# Altium schematic sheet S7CAS-PLEIADES-CARTE-MERE_P07_ETH.SchDoc(S7CAS-PLEIADES-CARTE-MERE_P07_ETH)
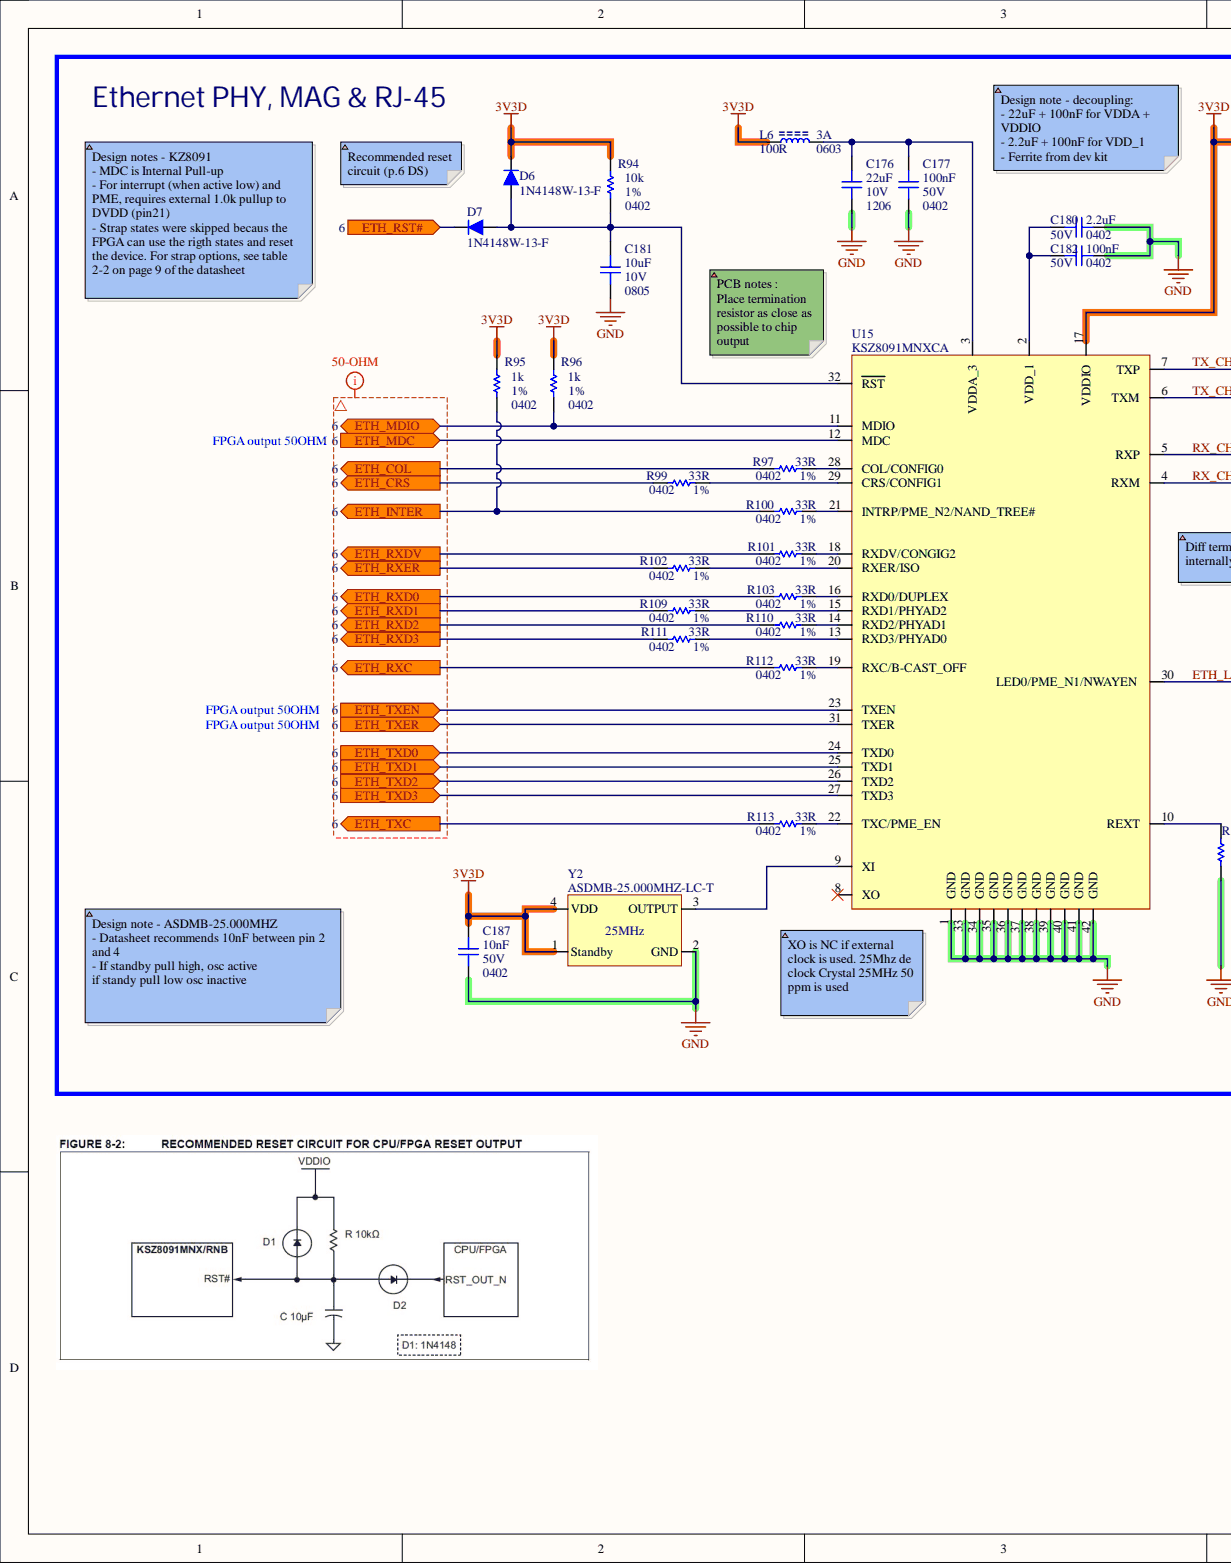
[diagram p0: S7CAS-PLEIADES-CARTE-MERE_P07_ETH.SchDoc, left]
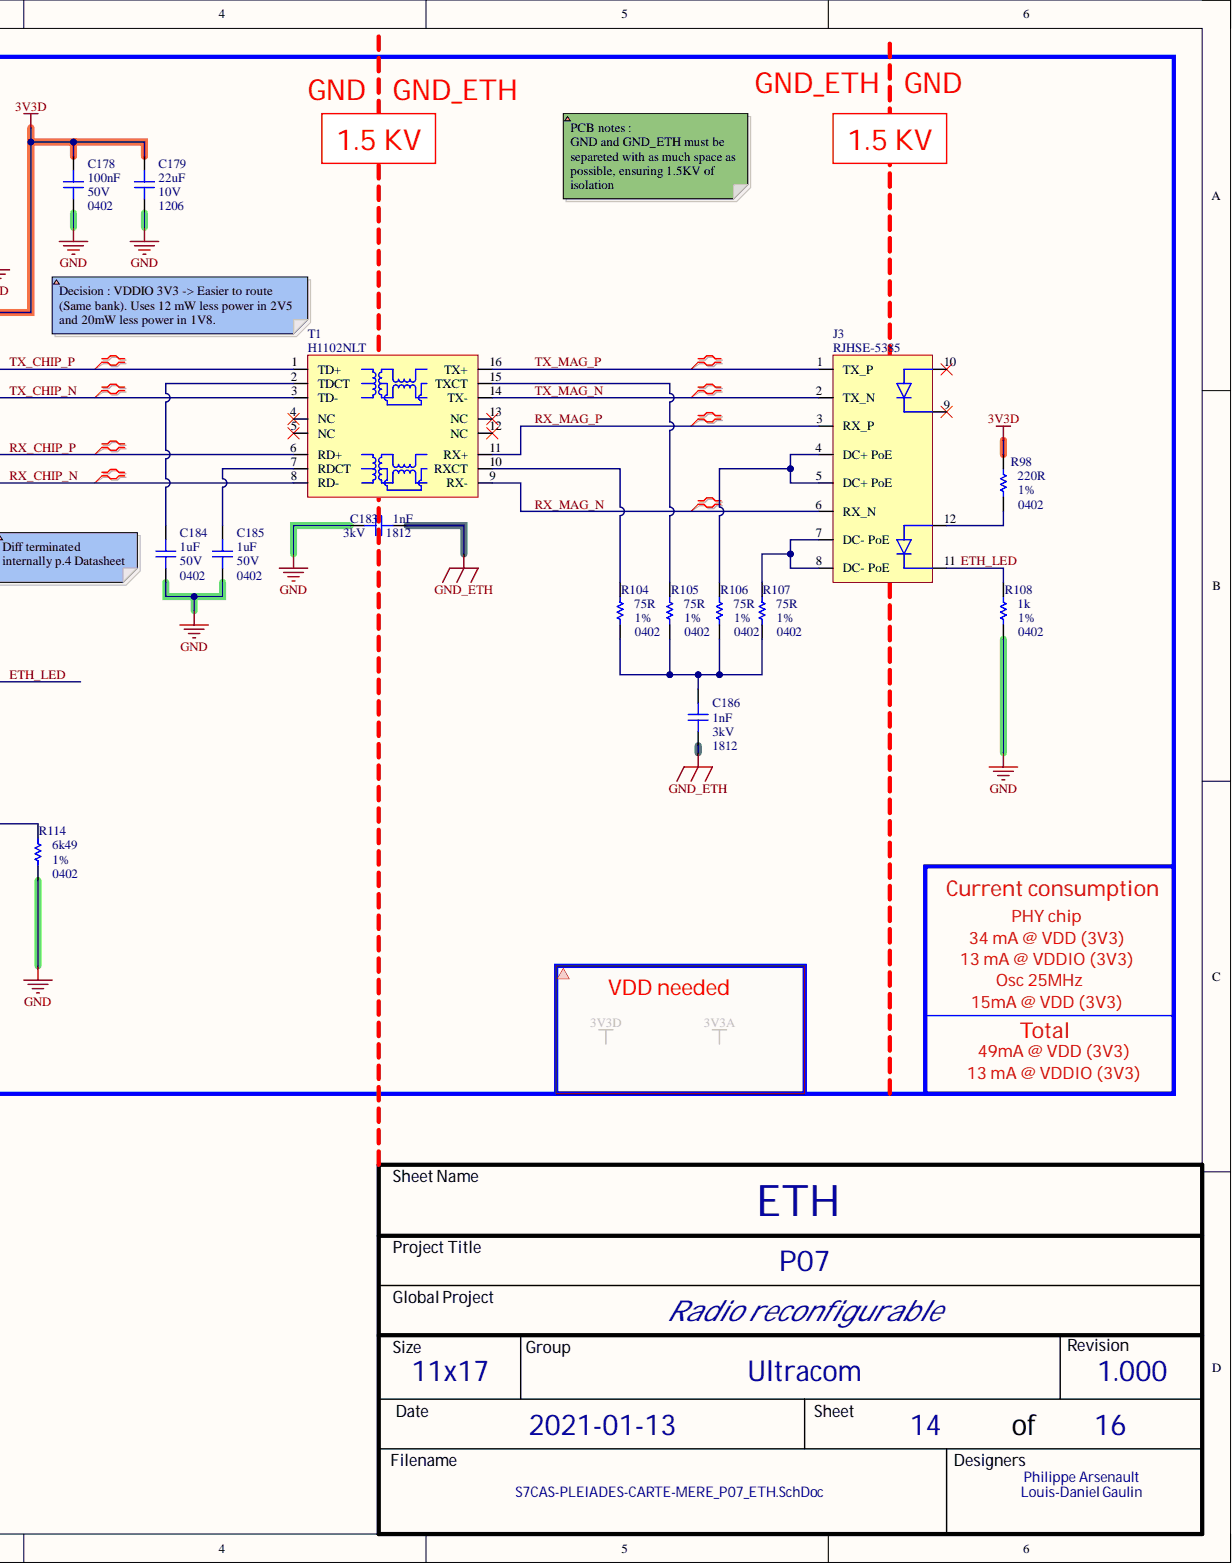
[diagram p1: S7CAS-PLEIADES-CARTE-MERE_P07_ETH.SchDoc, right]

## Parts
C176  value 22uF  Package: 1206  Voltage: 10V  [CAP_1206]  (hidden comment: CAP - 1206)
C177  value 100nF  Package: 0402  Voltage: 50V  [CAP_0402]  (hidden comment: CAP - 0402)
C178  value 100nF  Package: 0402  Voltage: 50V  [CAP_0402]  (hidden comment: CAP - 0402)
C179  value 22uF  Package: 1206  Voltage: 10V  [CAP_1206]  (hidden comment: CAP - 1206)
C180  value 2.2uF  Package: 0402  Voltage: 50V  [CAP_0402]  (hidden comment: CAP - 0402)
C181  value 10uF  Package: 0805  Voltage: 10V  [CAP_0805]  (hidden comment: CAP - 0805)
C182  value 100nF  Package: 0402  Voltage: 50V  [CAP_0402]  (hidden comment: CAP - 0402)
C183  value 1nF  Package: 1812  Voltage: 3kV  [CAP_1812]  (hidden comment: CAP - 1812)
C184  value 1uF  Package: 0402  Voltage: 50V  [CAP_0402]  (hidden comment: CAP - 0402)
C185  value 1uF  Package: 0402  Voltage: 50V  [CAP_0402]  (hidden comment: CAP - 0402)
C186  value 1nF  Package: 1812  Voltage: 3kV  [CAP_1812]  (hidden comment: CAP - 1812)
C187  value 10nF  Package: 0402  Voltage: 50V  [CAP_0402]  (hidden comment: CAP - 0402)
D6  1N4148W-13-F  [SOD123_DIO]
D7  1N4148W-13-F  [SOD123_DIO]
J3  RJHSE-5385  [CONN_ETHERNET_RJHSE-5385]
L6  value 100R  Current: 3A  Package: 0603  [FER_0603]  (hidden comment: FER - 0603)
R94  value 10k  Package: 0402  Precision: 1%  [RES_0402]  (hidden comment: RES - 0402)
R95  value 1k  Package: 0402  Precision: 1%  [RES_0402]  (hidden comment: RES - 0402)
R96  value 1k  Package: 0402  Precision: 1%  [RES_0402]  (hidden comment: RES - 0402)
R97  value 33R  Package: 0402  Precision: 1%  [RES_0402]  (hidden comment: RES - 0402)
R98  value 220R  Package: 0402  Precision: 1%  [RES_0402]  (hidden comment: RES - 0402)
R99  value 33R  Package: 0402  Precision: 1%  [RES_0402]  (hidden comment: RES - 0402)
R100  value 33R  Package: 0402  Precision: 1%  [RES_0402]  (hidden comment: RES - 0402)
R101  value 33R  Package: 0402  Precision: 1%  [RES_0402]  (hidden comment: RES - 0402)
R102  value 33R  Package: 0402  Precision: 1%  [RES_0402]  (hidden comment: RES - 0402)
R103  value 33R  Package: 0402  Precision: 1%  [RES_0402]  (hidden comment: RES - 0402)
R104  value 75R  Precision: 1%  [RES_0402]  (hidden comment: RES - 0402)
R105  value 75R  Package: 0402  Precision: 1%  [RES_0402]  (hidden comment: RES - 0402)
R106  value 75R  Package: 0402  Precision: 1%  [RES_0402]  (hidden comment: RES - 0402)
R107  value 75R  Package: 0402  Precision: 1%  [RES_0402]  (hidden comment: RES - 0402)
R108  value 1k  Package: 0402  Precision: 1%  [RES_0402]  (hidden comment: RES - 0402)
R109  value 33R  Package: 0402  Precision: 1%  [RES_0402]  (hidden comment: RES - 0402)
R110  value 33R  Package: 0402  Precision: 1%  [RES_0402]  (hidden comment: RES - 0402)
R111  value 33R  Package: 0402  Precision: 1%  [RES_0402]  (hidden comment: RES - 0402)
R112  value 33R  Package: 0402  Precision: 1%  [RES_0402]  (hidden comment: RES - 0402)
R113  value 33R  Package: 0402  Precision: 1%  [RES_0402]  (hidden comment: RES - 0402)
R114  value 6k49  Package: 0402  Precision: 1%  [RES_0402]  (hidden comment: RES - 0402)
T1  H1102NLT  [TRANSFO_H1102NLT]
U15  KSZ8091MNXCA  [COM_ETH_PHY_KSZ8091MNXCA]
Y2  ASDMB-25.000MHZ-LC-T  value 25MHz  [OSC_ASDMB-25.000MHZ-LC-T]

## Ports
ETH_COL, ETH_CRS, ETH_INTER, ETH_MDC, ETH_MDIO, ETH_RST#, ETH_RXC, ETH_RXD0, ETH_RXD1, ETH_RXD2, ETH_RXD3, ETH_RXDV, ETH_RXER, ETH_TXC, ETH_TXD0, ETH_TXD1, ETH_TXD2, ETH_TXD3, ETH_TXEN, ETH_TXER

## Nets
3V3D: C178.2 C179.2 C187.2 D6.1 L6.2 R94.2 R95.2 R96.2 R98.2 U15.17(VDDIO) Y2.1(Standby) Y2.4(VDD)
GND: C176.1 C177.1 C178.1 C179.1 C180.1 C181.1 C182.1 C183.2 C184.1 C185.1 C187.1 R108.1 R114.1 U15.1(GND) U15.33(GND) U15.34(GND) U15.35(GND) U15.36(GND) U15.37(GND) U15.38(GND) U15.39(GND) U15.40(GND) U15.41(GND) U15.42(GND) Y2.2(GND)
GND_ETH: C183.1 C186.2
ETH_COL: R97.2 ; port ETH_COL
ETH_CRS: R99.2 ; port ETH_CRS
ETH_INTER: R95.1 R100.2 ; port ETH_INTER
ETH_LED: J3.11 R108.2 U15.30(LED0/PME_N1/NWAYEN)
ETH_MDC: U15.12(MDC) ; port ETH_MDC
ETH_MDIO: R96.1 U15.11(MDIO) ; port ETH_MDIO
ETH_RST#: D7.1 ; port ETH_RST#
ETH_RXC: R112.2 ; port ETH_RXC
ETH_RXD0: R103.2 ; port ETH_RXD0
ETH_RXD1: R109.2 ; port ETH_RXD1
ETH_RXD2: R110.2 ; port ETH_RXD2
ETH_RXD3: R111.2 ; port ETH_RXD3
ETH_RXDV: R101.2 ; port ETH_RXDV
ETH_RXER: R102.2 ; port ETH_RXER
ETH_TXC: R113.2 ; port ETH_TXC
ETH_TXD0: U15.24(TXD0) ; port ETH_TXD0
ETH_TXD1: U15.25(TXD1) ; port ETH_TXD1
ETH_TXD2: U15.26(TXD2) ; port ETH_TXD2
ETH_TXD3: U15.27(TXD3) ; port ETH_TXD3
ETH_TXEN: U15.23(TXEN) ; port ETH_TXEN
ETH_TXER: U15.31(TXER) ; port ETH_TXER
RX_CHIP_N: T1.8(RD-) U15.4(RXM)
RX_CHIP_P: T1.6(RD+) U15.5(RXP)
RX_MAG_N: J3.6(RX_N) T1.9(RX-)
RX_MAG_P: J3.3(RX_P) T1.11(RX+)
TX_CHIP_N: T1.3(TD-) U15.6(TXM)
TX_CHIP_P: T1.1(TD+) U15.7(TXP)
TX_MAG_N: J3.2(TX_N) T1.14(TX-)
TX_MAG_P: J3.1(TX_P) T1.16(TX+)
NetC176_2: C176.2 C177.2 L6.1 U15.3(VDDA_3)
NetC180_2: C180.2 C182.2 U15.2(VDD_1)
NetC181_2: C181.2 D6.2 D7.2 R94.1 U15.32(RST)
NetC184_2: C184.2 T1.2(TDCT)
NetC185_2: C185.2 T1.7(RDCT)
NetC186_1: C186.1 R104.1 R105.1 R106.1 R107.1
NetJ3_4: J3.4(DC+_PoE) J3.5(DC+_PoE) R106.2
NetJ3_7: J3.7(DC-_PoE) J3.8(DC-_PoE) R107.2
NetJ3_12: J3.12 R98.1
NetR97_1: R97.1 U15.28(COL/CONFIG0)
NetR99_1: R99.1 U15.29(CRS/CONFIG1)
NetR100_1: R100.1 U15.21(INTRP/PME_N2/NAND_TREE#)
NetR101_1: R101.1 U15.18(RXDV/CONGIG2)
NetR102_1: R102.1 U15.20(RXER/ISO)
NetR103_1: R103.1 U15.16(RXD0/DUPLEX)
NetR104_2: R104.2 T1.10(RXCT)
NetR105_2: R105.2 T1.15(TXCT)
NetR109_1: R109.1 U15.15(RXD1/PHYAD2)
NetR110_1: R110.1 U15.14(RXD2/PHYAD1)
NetR111_1: R111.1 U15.13(RXD3/PHYAD0)
NetR112_1: R112.1 U15.19(RXC/B-CAST_OFF)
NetR113_1: R113.1 U15.22(TXC/PME_EN)
NetR114_2: R114.2 U15.10(REXT)
NetU15_9: U15.9(XI) Y2.3(OUTPUT)

Not connected: J3 9 10; T1 4(NC) 5(NC) 12(NC) 13(NC); U15 8(XO)

## Library data of the parts
C176 C179 = CAP - 1206: Precision: 10%; Component Kind: Standard; Description: CAP - 1206; Library Name: S7CAS_LIB2021.IntLib; CreatedBy: Jonathan Bouchard
C177 C178 C180 C182 C184 C185 C187 = CAP - 0402: Precision: 10%; Component Kind: Standard; Description: CAP - 0402; Library Name: S7CAS_LIB2021.IntLib; CreatedBy: Jonathan Bouchard
C181 = CAP - 0805: Mfg: CL21B106KPQNNNE; Precision: 10%; Component Kind: Standard; Description: CAP - 0805; Library Name: S7CAS_LIB2021.IntLib; CreatedBy: Jonathan Bouchard
C183 C186 = CAP - 1812: Mfg Part Number: 1812HC102MAT1A; Precision: 10%; Component Kind: Standard; Description: CAP - 1812; Library Name: S7CAS_P07.IntLib; CreatedBy: Jonathan Bouchard
D6 D7 = DIO_1N4148W-13-F: 1N4148; Built_By: EMA_UL_Team; SourceLibrary: DiodesInc_2015-05-08; Type: DEV; Vendor: Diodes Inc; Component Kind: Standard; Library Name: S7CAS_P07.IntLib; Manufacturer_Part_Number: 1N4148W-13-F; RefDes: RefDes; Copyright: Copyright (C) 2018 Accelerated Designs. All rights reserved
J3 = CONN_ETHERNET_RJHSE-5385: CONN - MOD JACK RJ45 R/A ETHERNET - TH; Mfg: Amphenol; Component Kind: Standard; HelpURL: https://www.amphenolcanada.com/ProductSearch/drawings/AC/RJHSE538X.pdf; Library Name: S7CAS_LIB2021.SchLib; Mfg Part Number: RJHSE-5385; Package: TH-12; Supplier 1: Digikey; Supplier Part Number 1: RJHSE-5385-ND; Temperature: -55°C ~ 85°C; CreatedBy: Jonathan Bouchard
R94-R114 = RES - 0402: Power: 1/16W; Voltage: 50V; Component Kind: Standard; Description: RES - 0402; Library Name: S7CAS_LIB2021.IntLib; CreatedBy: Jonathan Bouchard
  R104: Package: 0402
T1 = TRANSFO_H1102NLT: TRANSFO - ETHERNET 10/100B-TX - SMD-16; Mfg: Pulse Eng.; Value: 1:1 (350uH); Component Kind: Standard; HelpURL: http://media.digikey.com/pdf/Data%20Sheets/Pulse%20PDFs/10_100BASE-T%20Single%20Port%20SMD%20Magnetics_Rev2008.pdf; Library Name: S7CAS_LIB2021.SchLib; Mfg Part Number: H1102NLT; Package: SMD-16; Supplier 1: Digikey; Supplier Part Number 1: 553-1532-1-ND; Temperature: -40°C to 85°C; CreatedBy: Jonathan Bouchard
U15 = COM_ETH_PHY_KSZ8091MNXCA: COM - ETHERNET PHY 10/100 - QFN-32; Mfg: Micrel; Voltage: 1.8V, 2.5V, 3.3V; Component Kind: Standard; HelpURL: http://ww1.microchip.com/downloads/en/DeviceDoc/00002275A.pdf; Library Name: S7CAS_LIB2021.SchLib; Mfg Part Number: KSZ8091MNXCA; Package: QFN-32; Supplier 1: Digikey; Supplier Part Number 1: 576-4356-ND; Temperature: 0°C ~ 70°C; CreatedBy: Jonathan Bouchard
Y2 = OSC_ASDMB-25.000MHZ-LC-T: OSC - 25MHz XO (Standard) LVCMOS - 4-SMD, No Lead; Manufacturer #1: Abracon LLC; Cryo: UNKNOWN; GRAMS Lib Spec: 2.8; Polarized: Yes; Tolerance: ±50ppm; Voltage Rating: 1.8V ~ 3.3V; Assembly Type: SMD; Component Kind: Standard; HelpURL: https://abracon.com/Oscillators/ASDMB.pdf; Library Name: S7CAS_LIB2021.IntLib; Magnetic Material: Unknown; Package: 4-SMD, No Lead; Part Number #1: ASDMB-25.000MHZ-LC-T; Supplier 1: Digi-Key; Supplier Part Number 1: 535-11730-1-ND; Temperature: -40°C ~ +85°C; CreatedBy/Date: Joël Lemay 2019-03-29; VerifiedBy: C. Paulin - 29 mai 2019
L6 = FER - 0603: Component Kind: Standard; Description: FER - 0603; Library Name: S7CAS_LIB2021.IntLib; CreatedBy: Jonathan Bouchard
